# Altium schematic sheet ESP32-S3-0.96LCD.SchDoc
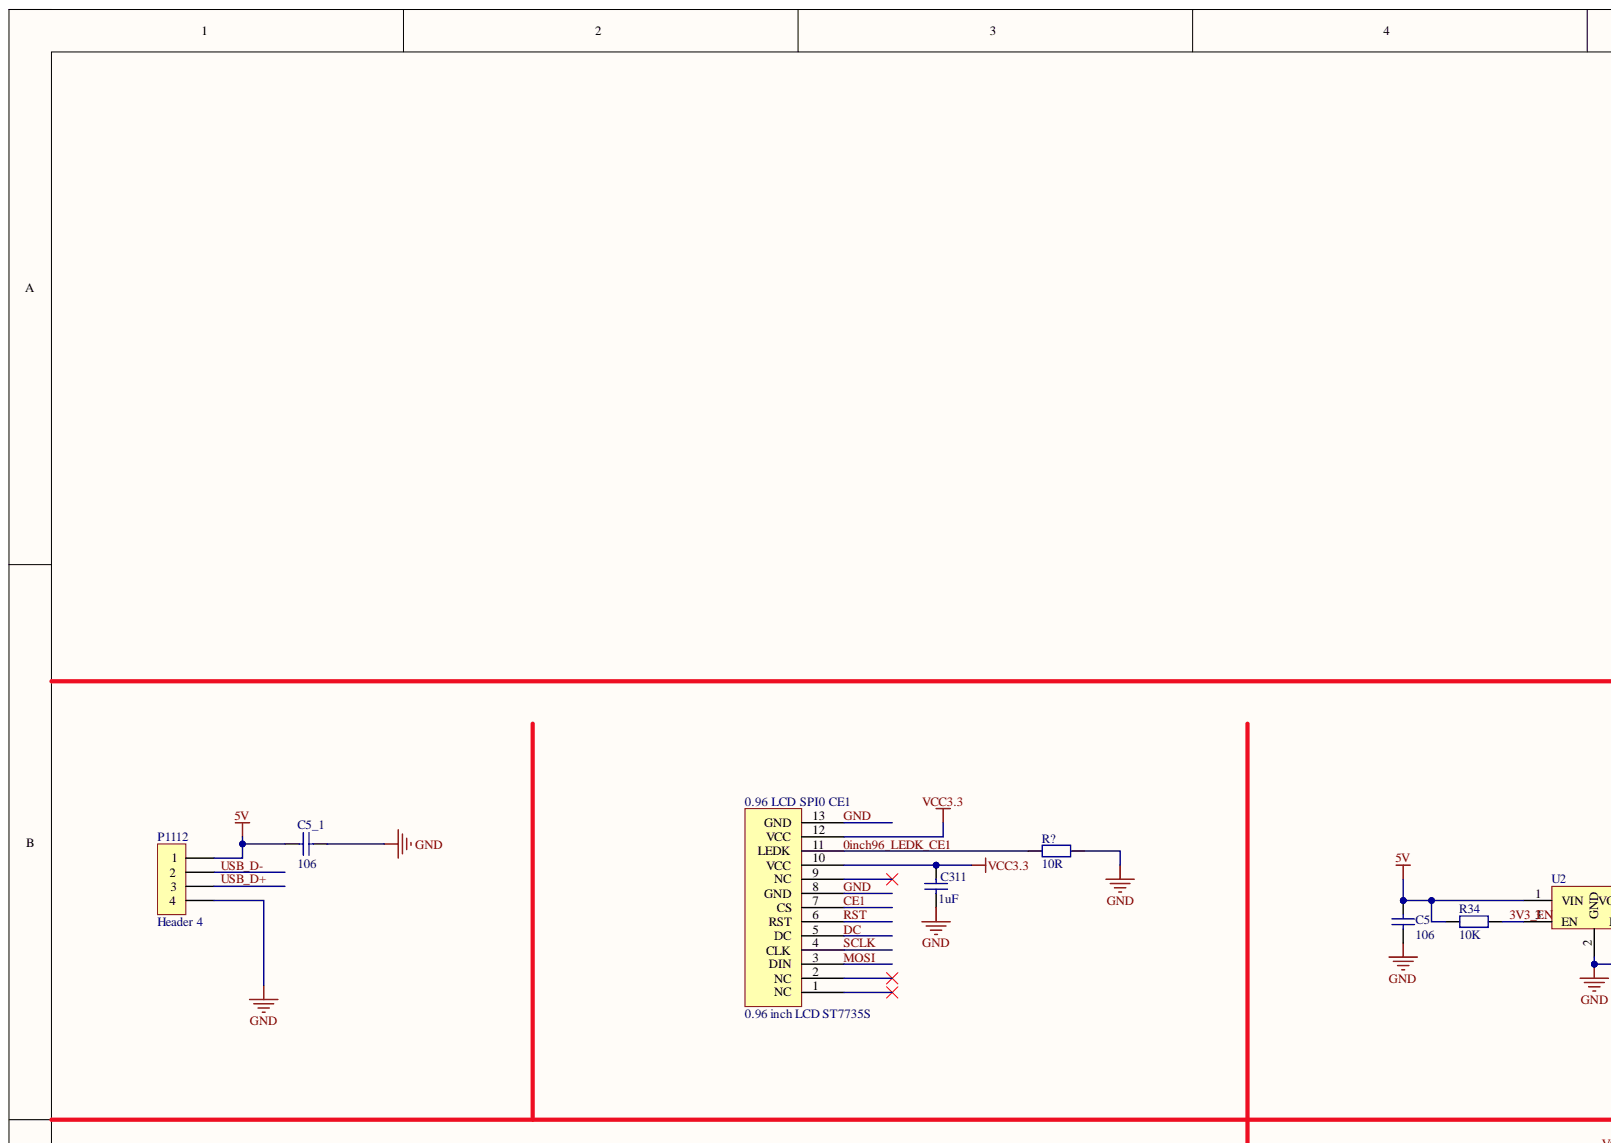
[diagram p0: ESP32-S3-0.96LCD.SchDoc, top left]
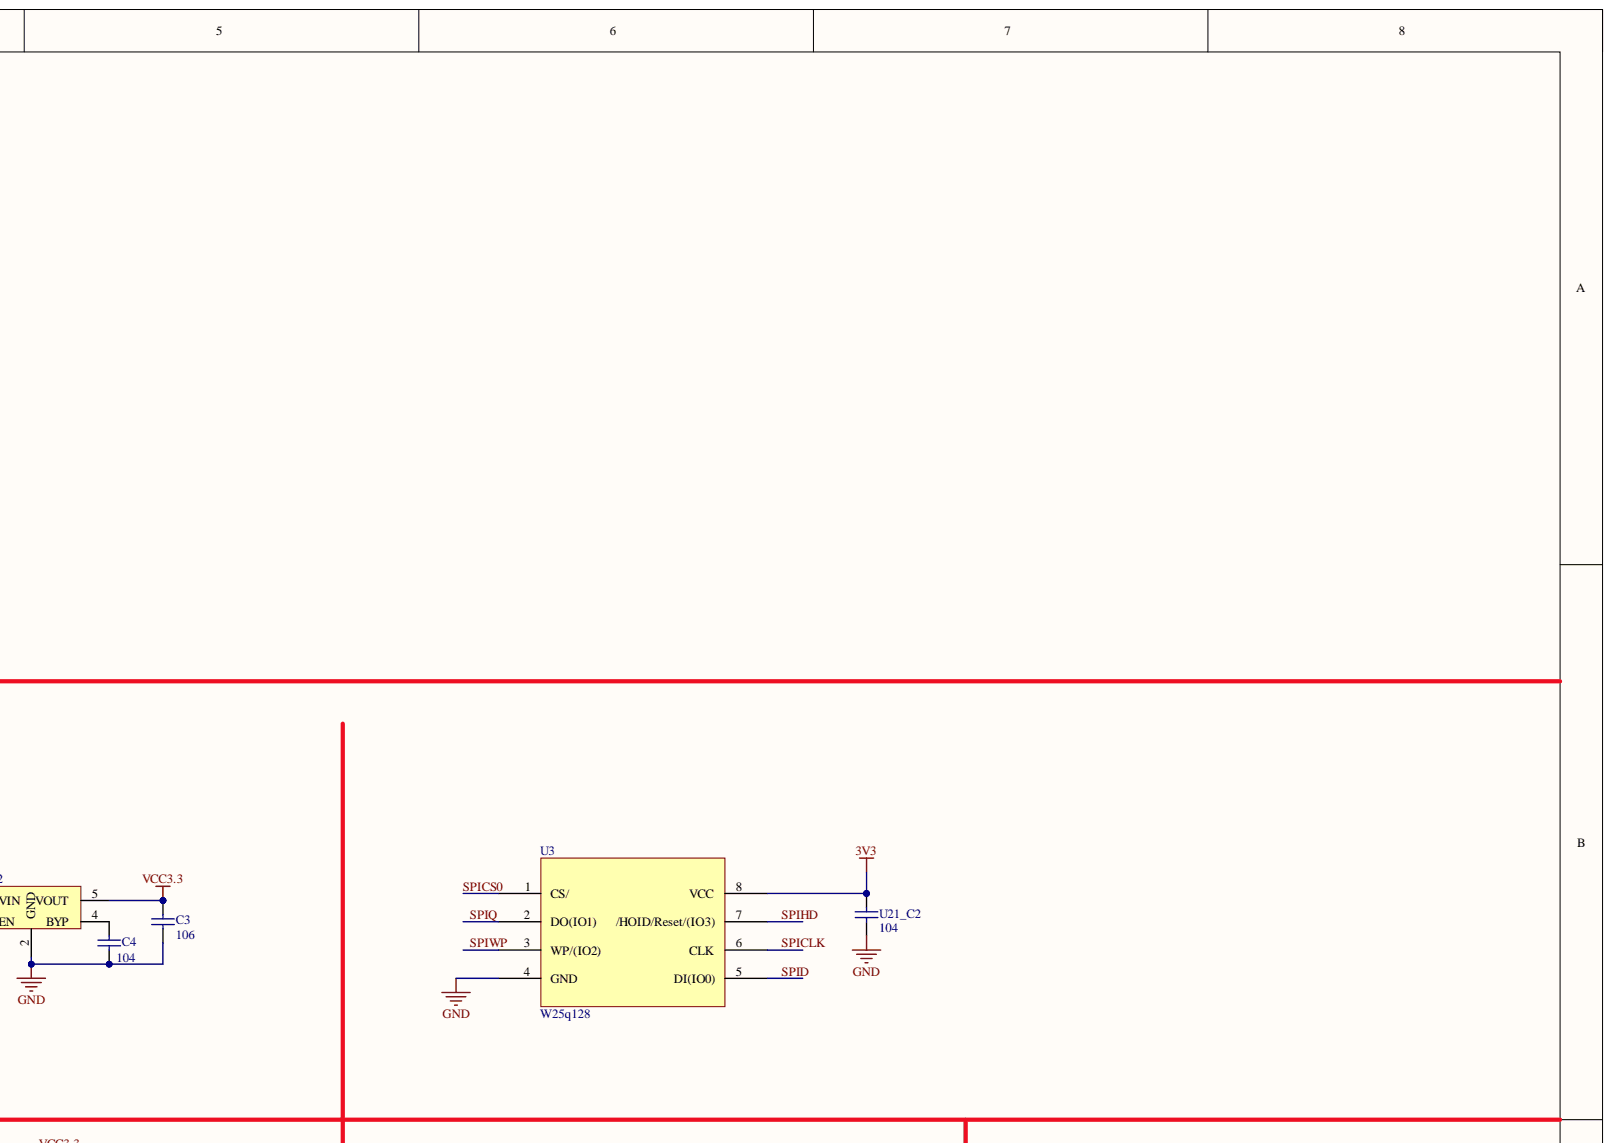
[diagram p1: ESP32-S3-0.96LCD.SchDoc, top right]
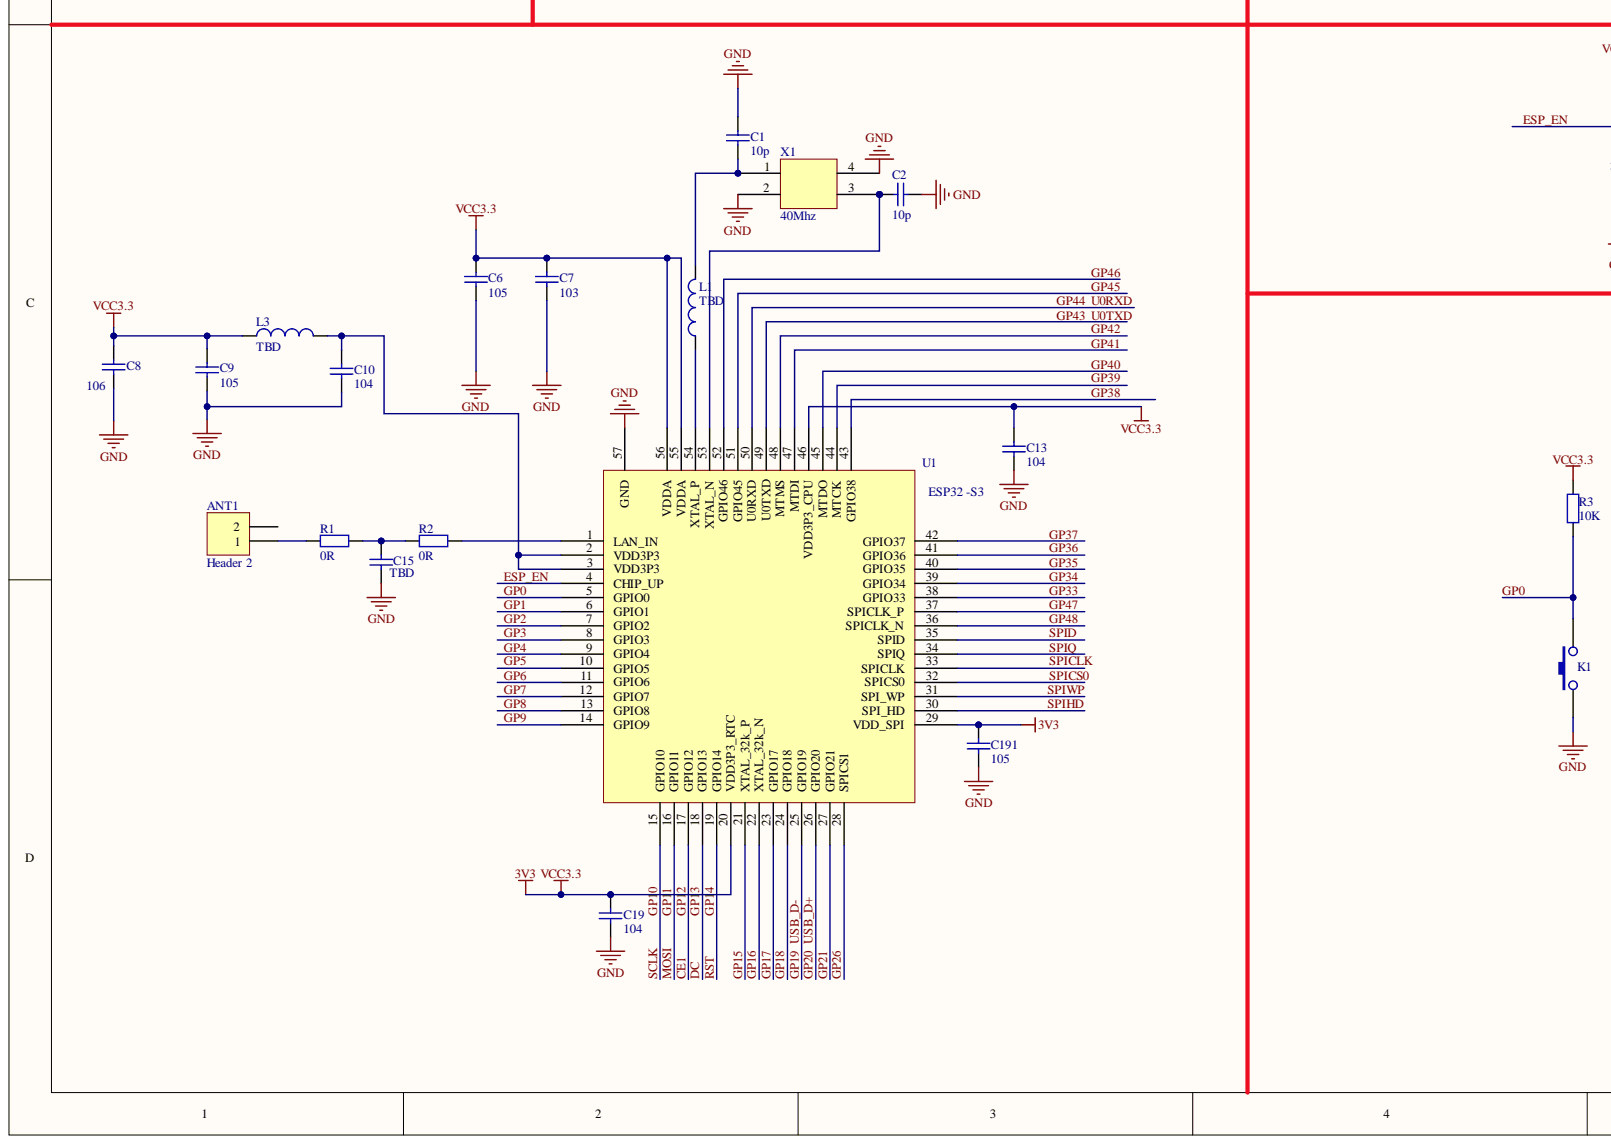
[diagram p2: ESP32-S3-0.96LCD.SchDoc, bottom left]
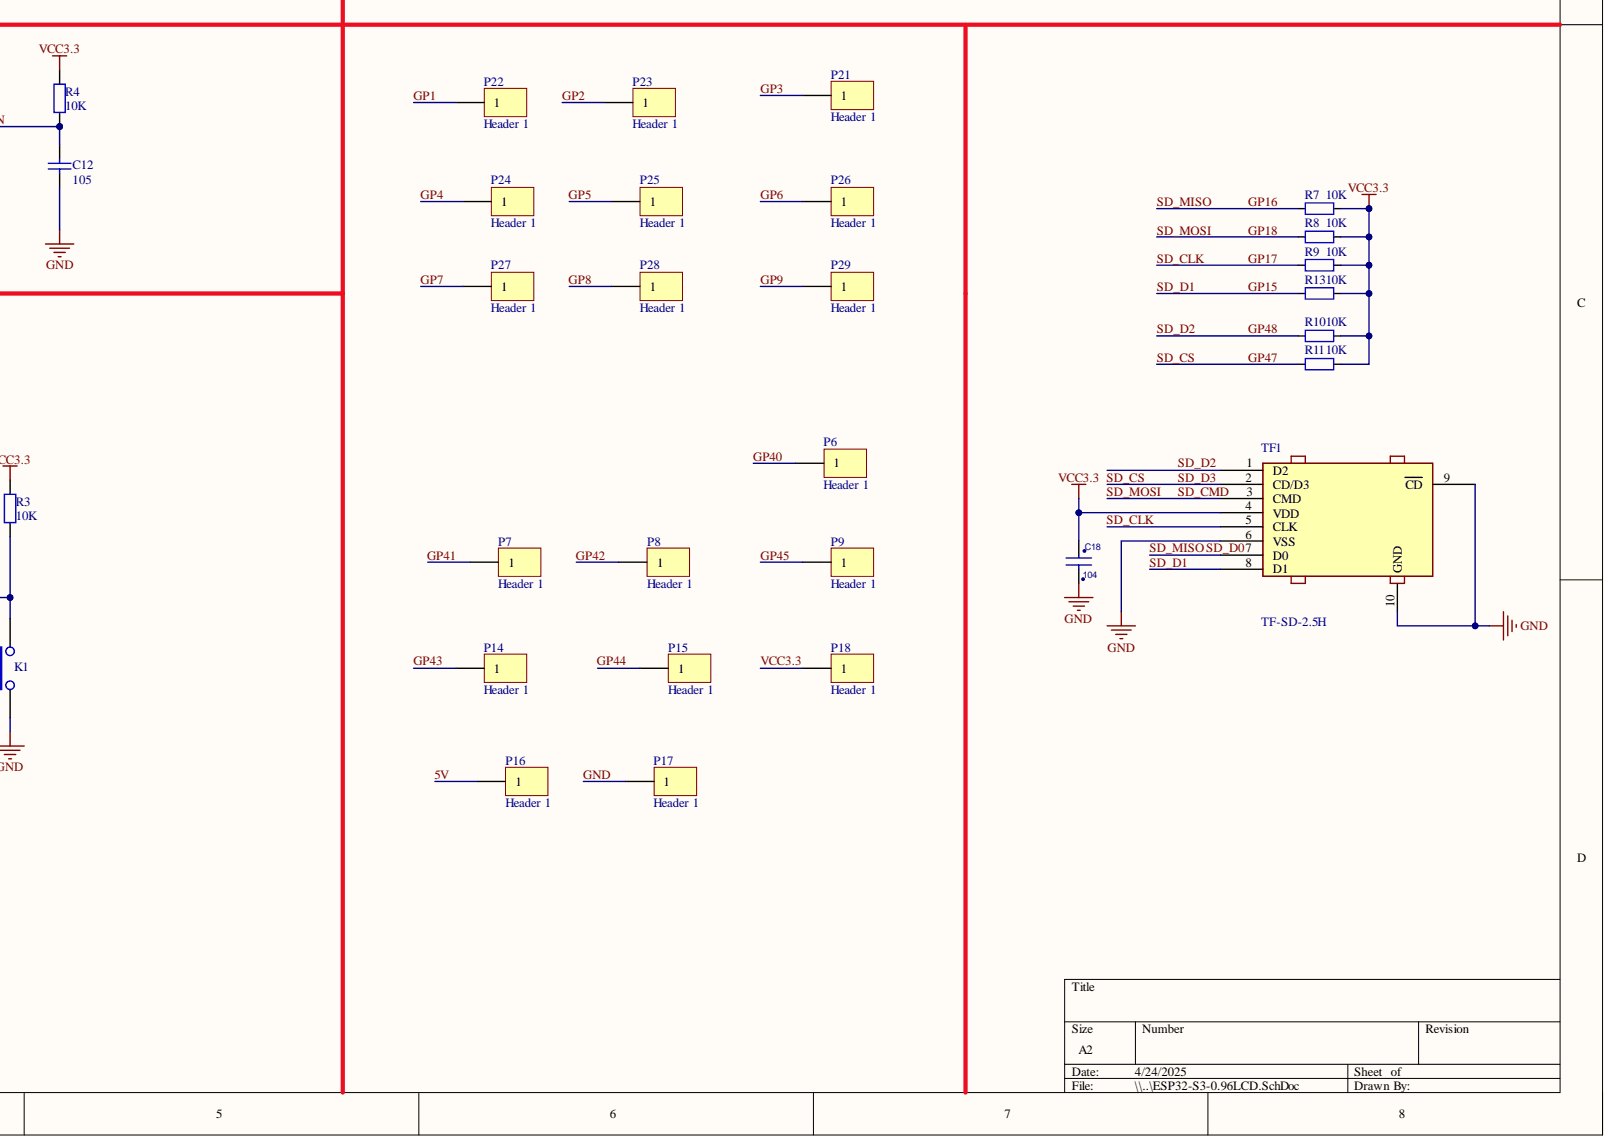
[diagram p3: ESP32-S3-0.96LCD.SchDoc, bottom right]

## Parts
0.96_LCD_SPI0_CE1  0.96 inch LCD ST7735S  [0.96INCH LCD]
ANT1  Header 2  [ANT_rainsun]
C1  10p  [C0201]
C2  10p  [C0201]
C3  106  [C0402]
C4  104  [C0201]
C5  106  [C0402]
C5_1  106  [C0402]
C6  105  [C0201]
C7  103  [C0201]
C8  106  [c0402]
C9  105  [C0201]
C10  104  [C0201]
C12  105  [C0201]
C13  104  [C0201]
C15  TBD  [C0201]
C18  104  [C0201]
C19  104  [c0201]
C191  105  [c0201]
C311  1uF  [C0201]
K1  KEY2  [SW-SMD_L3.9-W3.0-P4.45]  (value not drawn)
L1  value TBD  [R0201]  (hidden comment: Inductor)
L3  value TBD  [R0201]  (hidden comment: Inductor)
P6  Header 1  [TP1]
P7  Header 1  [TP1]
P8  Header 1  [TP1]
P9  Header 1  [TP1]
P14  Header 1  [TP1]
P15  Header 1  [TP1]
P16  Header 1  [TP1]
P17  Header 1  [TP1]
P18  Header 1  [TP1]
P21  Header 1  [TP1]
P22  Header 1  [TP1]
P23  Header 1  [TP1]
P24  Header 1  [TP1]
P25  Header 1  [TP1]
P26  Header 1  [TP1]
P27  Header 1  [TP1]
P28  Header 1  [TP1]
P29  Header 1  [TP1]
P1112  Header 4  [USB-BT]
R1  value 0R  [R0201]  (hidden comment: Res2)
R2  value 0R  [R0201]  (hidden comment: Res2)
R3  value 10K  [R0201]  (hidden comment: Res2)
R4  value 10K  [R0201]  (hidden comment: Res2)
R7  10K  [r0201]
R8  10K  [r0201]
R9  10K  [r0201]
R10  10K  [r0201]  (value not drawn)
R11  10K  [r0201]  (value not drawn)
R13  10K  [r0201]
R34  value 10K  [R0201]  (hidden comment: Res2)
R?  value 10R  [R0201]  (hidden comment: Res2)
TF1  TF-SD-2.5H  [TF-SMD_H2.8 短体]
U1  ESP32 -S3  [QFN-56_L7.0-W7.0-P0.40-TL-EP4.0]
U2  CAT6219  [TPS61040]  (value not drawn)
U3  W25q128  [W25Q80]
U21_C2  104  [C0201]
X1  40Mhz  [SMD2016]

## Nets
0inch96_LEDK_CE1: 0.96_LCD_SPI0_CE1.11(LEDK) R?.2
3V3_EN: R34.1 U2.3(EN)
5V: C5.1 C5_1.1 P16.1 P1112.1 R34.2 U2.1(VIN)
CE1: 0.96_LCD_SPI0_CE1.7(CS) U1.17(GPIO12)
DC: 0.96_LCD_SPI0_CE1.5(DC) U1.18(GPIO13)
ESP_EN: C12.2 R4.2 U1.4(CHIP_UP)
GND: 0.96_LCD_SPI0_CE1.8(GND) 0.96_LCD_SPI0_CE1.13(GND) C1.1 C2.1 C3.2 C4.2 C5.2 C5_1.2 C6.1 C7.1 C8.1 C9.1 C10.1 C12.1 C13.1 C15.1 C18.2 C19.1 C191.1 C311.2 K1.1 P17.1 P1112.4 R?.1 TF1.6(VSS) TF1.9(CD) TF1.10(GND) U1.57(GND) U2.2(GND) U3.4(GND) U21_C2.2 X1.2 X1.4
GP0: K1.2 R3.2 U1.5(GPIO0)
GP1: P22.1 U1.6(GPIO1)
GP2: P23.1 U1.7(GPIO2)
GP3: P21.1 U1.8(GPIO3)
GP4: P24.1 U1.9(GPIO4)
GP5: P25.1 U1.10(GPIO5)
GP6: P26.1 U1.11(GPIO6)
GP7: P27.1 U1.12(GPIO7)
GP8: P28.1 U1.13(GPIO8)
GP9: P29.1 U1.14(GPIO9)
GP10: 0.96_LCD_SPI0_CE1.4(CLK) U1.15(GPIO10)
GP11: 0.96_LCD_SPI0_CE1.3(DIN) U1.16(GPIO11)
GP14: 0.96_LCD_SPI0_CE1.6(RST) U1.19(GPIO14)
GP15: R13.2 TF1.8(D1) U1.21(XTAL_32k_P)
GP16: R7.2 TF1.7(D0) U1.22(XTAL_32k_N)
GP17: R9.2 TF1.5(CLK) U1.23(GPIO17)
GP18: R8.2 TF1.3(CMD) U1.24(GPIO18)
GP19: P1112.2 U1.25(GPIO19)
GP20: P1112.3 U1.26(GPIO20)
GP21: U1.27(GPIO21)
GP26: U1.28(SPICS1)
GP33: U1.38(GPIO33)
GP34: U1.39(GPIO34)
GP35: U1.40(GPIO35)
GP36: U1.41(GPIO36)
GP37: U1.42(GPIO37)
GP38: U1.43(GPIO38)
GP39: U1.44(MTCK)
GP40: P6.1 U1.45(MTDO)
GP41: P7.1 U1.47(MTDI)
GP42: P8.1 U1.48(MTMS)
GP43: P14.1 U1.49(U0TXD)
GP44: P15.1 U1.50(U0RXD)
GP45: P9.1 U1.51(GPIO45)
GP46: U1.52(GPIO46)
GP47: R11.2 TF1.2(CD/D3) U1.37(SPICLK_P)
GP48: R10.2 TF1.1(D2) U1.36(SPICLK_N)
SPICLK: U1.33(SPICLK) U3.6(CLK)
SPICS0: U1.32(SPICS0) U3.1(CS/)
SPID: U1.35(SPID) U3.5(DI(IO0))
SPIHD: U1.30(SPI_HD) U3.7(/HOID/Reset/(IO3))
SPIQ: U1.34(SPIQ) U3.2(DO(IO1))
SPIWP: U1.31(SPI_WP) U3.3(WP/(IO2))
VCC3.3: 0.96_LCD_SPI0_CE1.10(VCC) 0.96_LCD_SPI0_CE1.12(VCC) C3.1 C6.2 C7.2 C8.2 C9.2 C13.2 C18.1 C19.2 C191.2 C311.1 L3.1 P18.1 R3.1 R4.1 R7.1 R8.1 R9.1 R10.1 R11.1 R13.1 TF1.4(VDD) U1.20(VDD3P3_RTC) U1.29(VDD_SPI) U1.46(VDD3P3_CPU) U1.55(VDDA) U1.56(VDDA) U2.5(VOUT) U3.8(VCC) U21_C2.1
NetANT1_1: ANT1.1 R1.1
NetC1_2: C1.2 L1.2 X1.1
NetC2_2: C2.2 U1.53(XTAL_N) X1.3
NetC4_1: C4.1 U2.4(BYP)
NetC10_2: C10.2 L3.2 U1.2(VDD3P3) U1.3(VDD3P3)
NetC15_2: C15.2 R1.2 R2.1
NetL1_1: L1.1 U1.54(XTAL_P)
NetR2_2: R2.2 U1.1(LAN_IN)

Not connected: 0.96_LCD_SPI0_CE1 1(NC) 2(NC) 9(NC); ANT1 2

## Library data of the parts
ANT1 = Header 2: Header, 2-Pin; Component Kind: Standard; LatestRevisionDate: 17-Jul-2002; LatestRevisionNote: Re-released for DXP Platform.; Library Name: Miscellaneous Connectors.IntLib; Publisher: Altium Limited
C1 C2 C6-C10 C12 C13 C15 C19 C191 C311 = Cap: Capacitor; Value: 100pF; Component Kind: Standard; Library Name: zz-houxin.SchLib
C3 C5 C5_1 = Cap: Capacitor; Value: 104; Component Kind: Standard; Library Name: zz.SchLib
C4 U21_C2 = Cap: Capacitor; Component Kind: Standard; Library Name: zz.SchLib; Value: 104
C18 = CAP NP_Dup4: tolerance: 10%; Component Kind: Standard
L1 L3 = Inductor: Code_IEC: 1005; Code_JEITA: 0402; Component Kind: Standard; Description: Inductor; LatestRevisionDate: 29-May-2009; LatestRevisionNote: IPC-7351 Footprint Added.; Library Name: Miscellaneous Devices.IntLib; PackageDescription: Chip Inductor; PackageReference: 0402-A; Published: 8-Jun-2000; Publisher: Altium Limited
P6-P9 P14-P18 P21-P29 = Header 1: Header, 2-Pin; Component Kind: Standard; LatestRevisionDate: 17-Jul-2002; LatestRevisionNote: Re-released for DXP Platform.; Library Name: zz.SchLib; Publisher: Altium Limited
P1112 = Header 4: Header, 4-Pin; Component Kind: Standard; LatestRevisionDate: 17-Jul-2002; LatestRevisionNote: Re-released for DXP Platform.; Library Name: zz.SchLib; Publisher: Altium Limited
R1-R4 R34 R? = Res2: Resistor; Component Kind: Standard; LatestRevisionDate: 17-Jul-2002; LatestRevisionNote: Re-released for DXP Platform.; Library Name: Miscellaneous Devices.IntLib; PackageDescription: Axial Device, Thru-Hole; 2 Leads; 0.4 in Pin Spacing; PackageReference: AXIAL-0.4; Published: 8-Jun-2000; Publisher: Altium Limited
R7-R11 R13 = 电阻-0402: Resistor; Value: 22R; Component Kind: Standard; Library Name: MyPcbLib.IntLib
TF1 = TF-SD: Component Kind: Standard; Library Name: zz.SchLib
U1 = ESP32-S3: Component Kind: Standard; Library Name: zz-houxin.SchLib
U3 = FLASH W25Q128: Component Kind: Standard; Library Name: zz-houxin.SchLib
X1 = XTAL-3225: Component Kind: Standard; Library Name: zz.SchLib
0.96_LCD_SPI0_CE1 = 0.96 inch LCD ST7735S: Component Kind: Standard; Library Name: zz-houxin.SchLib
K1 = KEY2: Component Kind: Standard; Library Name: zz.SchLib
U2 = CAT6219: Component Kind: Standard; Library Name: zz.SchLib
